# Altium schematic sheet ESP32_wroom32EU.SchDoc
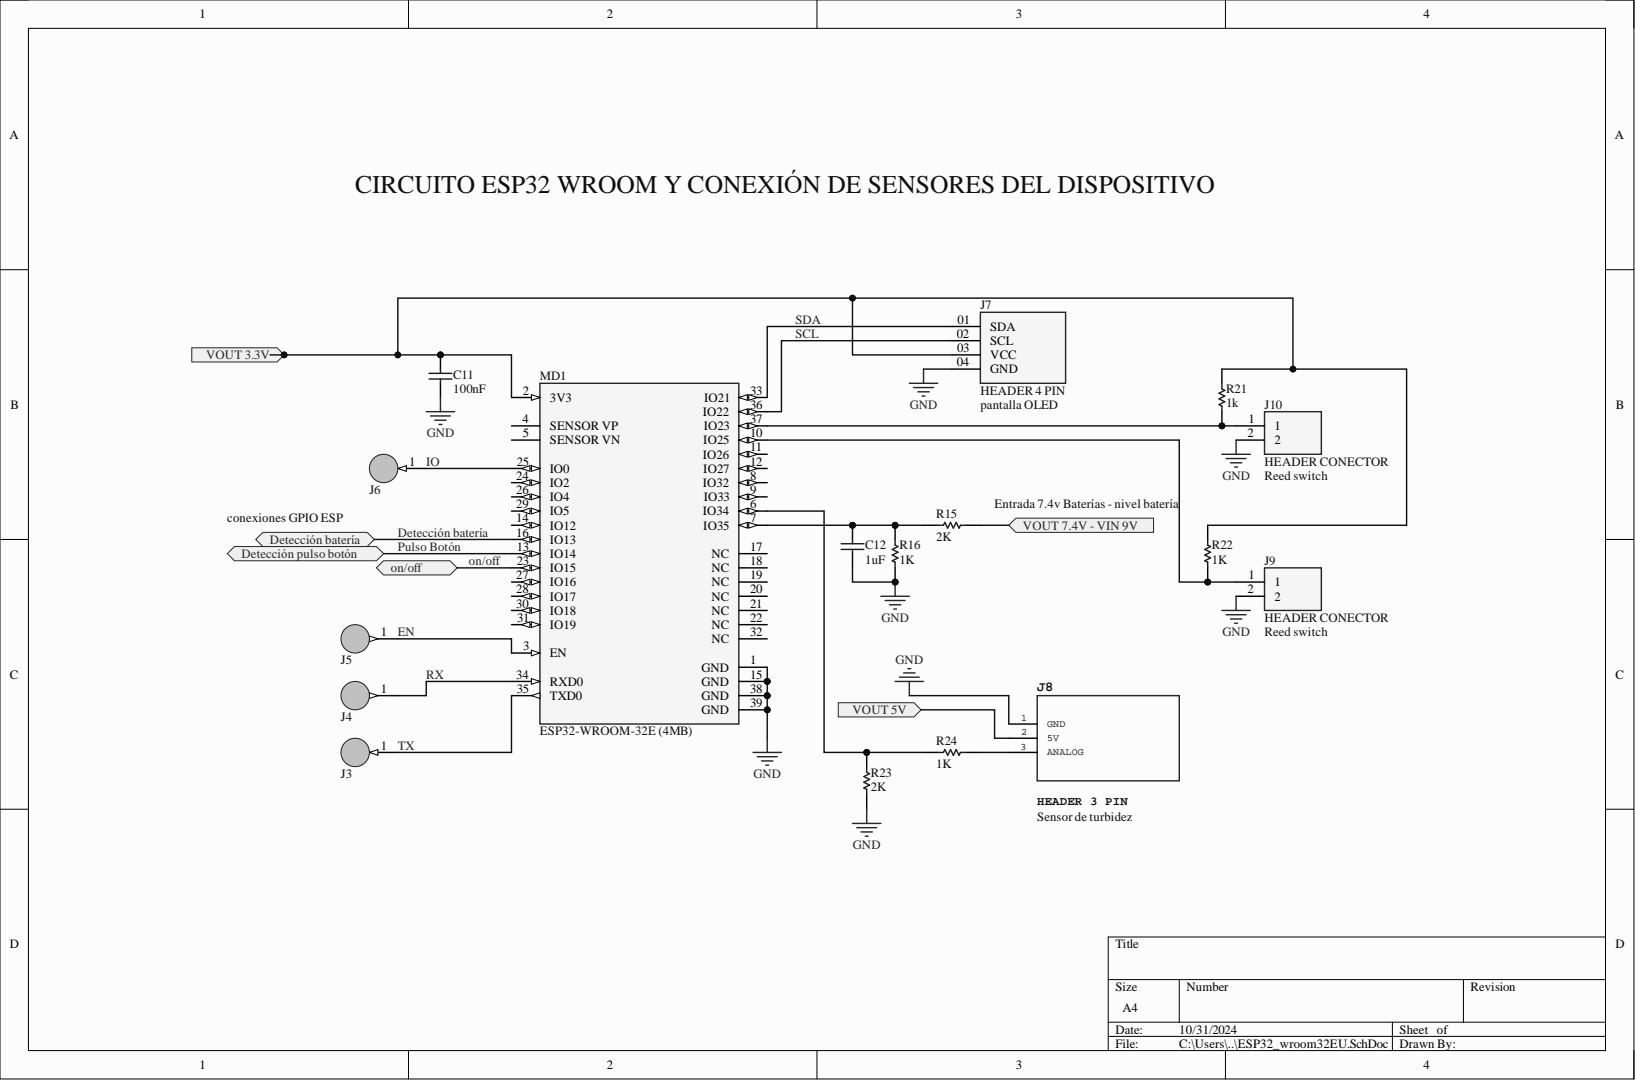
[diagram p0: ESP32_wroom32EU.SchDoc]

## Parts
C11  value 100nF  [CC0805]  (hidden comment: Cap)
C12  value 1uF  [CC0805]  (hidden comment: Cap)
J3  PAD_1  [PAD]  (value not drawn)
J4  PAD  [PAD]  (value not drawn)
J5  PAD  [PAD]  (value not drawn)
J6  PAD_1  [PAD]  (value not drawn)
J7  HEADER 4 PIN  [HEADER 4 PIN]
J8  HEADER 3 PIN  [HEADER 3 PIN]
J9  HEADER CONECTOR  [HEADER CONECTOR]
J10  HEADER CONECTOR  [HEADER CONECTOR]
MD1  ESP32-WROOM-32E (4MB)  [3FP-ESP32-WROOM-32E_4MB-MFG]
R15  value 2K  [RES 1206]  (hidden comment: 1%)
R16  1%  value 1K  [RES 1206]
R21  1%  value 1k  [RES 1206]
R22  1%  value 1K  [RES 1206]
R23  value 2K  [RES 1206]  (hidden comment: 1%)
R24  1%  value 1K  [RES 1206]

## Ports
Detección batería, Detección pulso botón, on/off, VOUT 3.3V, VOUT 5V, VOUT 7.4V - VIN 9V

## Nets
GND: C11.1 C12.1 J7.04(GND) J8.1 J9.2 J10.2 MD1.1(GND) MD1.15(GND) MD1.38(GND) MD1.39(GND) R16.1 R23.1
Detección batería: MD1.16(IO13) ; port Detección batería
EN: J5.1 MD1.3(EN)
IO: J6.1 MD1.25(IO0)
on/off: MD1.23(IO15) ; port on/off
Pulso Botón: MD1.13(IO14) ; port Detección pulso botón
RX: J4.1 MD1.34(RXD0)
SCL: J7.02(SCL) MD1.36(IO22)
SDA: J7.01(SDA) MD1.33(IO21)
TX: J3.1 MD1.35(TXD0)
VOUT 3.3V: C11.2 J7.03(VCC) MD1.2(3V3) R21.2 R22.2 ; port VOUT 3.3V
VOUT 5V: J8.2 ; port VOUT 5V
VOUT 7.4V - VIN 9V: R15.2 ; port VOUT 7.4V - VIN 9V
NetC12_2: C12.2 MD1.7(IO35) R15.1 R16.2
NetJ8_3: J8.3 R24.2
NetJ9_1: J9.1 MD1.10(IO25) R22.1
NetJ10_1: J10.1 MD1.37(IO23) R21.1
NetMD1_4: MD1.4(SENSOR_VP)
NetMD1_5: MD1.5(SENSOR_VN)
NetMD1_6: MD1.6(IO34) R23.2 R24.1
NetMD1_8: MD1.8(IO32)
NetMD1_9: MD1.9(IO33)
NetMD1_11: MD1.11(IO26)
NetMD1_12: MD1.12(IO27)
NetMD1_14: MD1.14(IO12)
NetMD1_17: MD1.17(NC)
NetMD1_18: MD1.18(NC)
NetMD1_19: MD1.19(NC)
NetMD1_20: MD1.20(NC)
NetMD1_21: MD1.21(NC)
NetMD1_22: MD1.22(NC)
NetMD1_24: MD1.24(IO2)
NetMD1_26: MD1.26(IO4)
NetMD1_27: MD1.27(IO16)
NetMD1_28: MD1.28(IO17)
NetMD1_29: MD1.29(IO5)
NetMD1_30: MD1.30(IO18)
NetMD1_31: MD1.31(IO19)
NetMD1_32: MD1.32(NC)

## Library data of the parts
C11 C12 = Cap_1: Capacitor; Component Kind: Standard; LatestRevisionDate: 17-Jul-2002; LatestRevisionNote: Re-released for DXP Platform.; PackageDescription: Radial Cap, Thru-Hole; 2 Leads; 0.3 in Pin Spacing; PackageReference: RAD-0.3; Published: 8-Jun-2000; Library Name: biblioteca esquematico sistema de drenaje.SchLib; Publisher: Altium Limited
J7 = HEADER 4 PIN: MP: MTSW-104-22-T-S-395; Availability: Unavailable; Component Kind: Standard; Package: None; Price: None; Library Name: biblioteca esquematico sistema de drenaje.SchLib
J8 = HEADER 3 PIN_1: DeviceName: J; DeviceSetName: SAMTEC-TSW-101-07-X-T; GateName_1: A; SymbolName_1: SAMTEC-TSW-101-07-X-TA; Component Kind: Standard; LibraryName: SAMTEC-TSW-101-07-X-T; Package: SAMTEC-TSW-101-07-X-T; Library Name: biblioteca esquematico sistema de drenaje.SchLib
J9 J10 = HEADER CONECTOR: Connector, MTA-156; Male; 2; Copper Alloy; 7; 600; 0.156 in.; Polyester; White; Centerline_Pitch: 3.96 mm[.156 in]; MF: TE Connectivity; MP: 640445-2; Number_of_Positions: 2; Component Kind: Standard; Digi-Key_Part_Number: A1971-ND; Digi-Key_Purchase_URL: https://www.digikey.com/product-detail/en/te-connectivity-amp-connectors/640445-2/A1971-ND/109093?utm_source=snapeda&utm_medium=aggregator&utm_campaign=symbol; EU_RoHS_Compliance: Compliant; Package: None; Product_Type: Header; SnapEDA_Link: https://www.snapeda.com/parts/640445-2/TE%20Connectivity%20AMP%20Connectors/view-part/356904/?ref=snap; TE_purchase_URL: https://www.te.com/usa-en/product-640445-2.html?te_bu=Cor&te_type=disp&te_campaign=seda_glo_cor-seda-global-disp-prtnr-fy19-seda-model-bom-cta_sma-317_1&elqCampaignId=32493; Library Name: biblioteca esquematico sistema de drenaje.SchLib
MD1 = WROOM-ESP32: RX TXRX MOD WIFI TRACE ANT SMD; Component Kind: Standard; Library Name: biblioteca esquematico sistema de drenaje.SchLib
R15 R16 R21-R24 = Resistor: Component Kind: Standard; Description: Resistor; LatestRevisionDate: 17-Jul-2002; LatestRevisionNote: Re-released for DXP Platform.; PackageDescription: Axial Device, Thru-Hole; 2 Leads; 0.3 in Pin Spacing; PackageReference: AXIAL-0.3; Published: 8-Jun-2000; Library Name: biblioteca esquematico sistema de drenaje.SchLib; Publisher: Altium Limited
J3 J6 = PAD_1: Component Kind: Standard; Library Name: biblioteca esquematico sistema de drenaje.SchLib
J4 J5 = PAD: Component Kind: Standard; Library Name: biblioteca esquematico sistema de drenaje.SchLib
